# Altium schematic sheet Relay.SchDoc
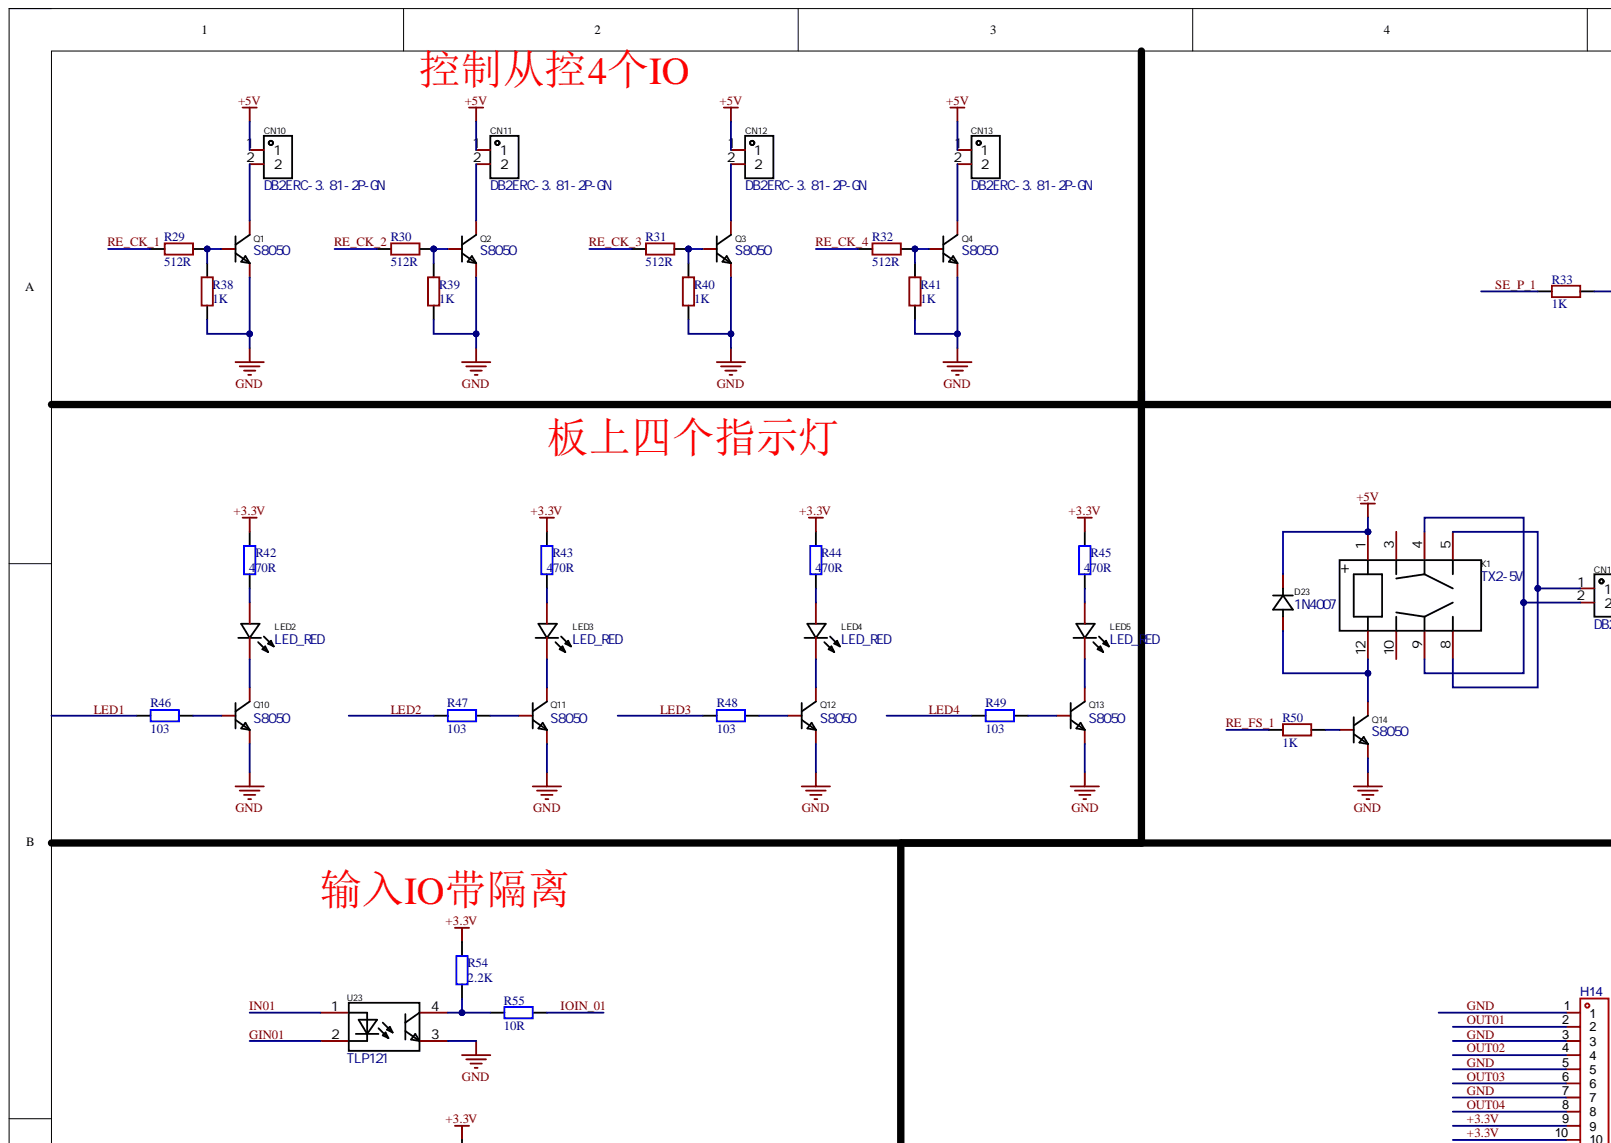
[diagram p0: Relay.SchDoc, top left]
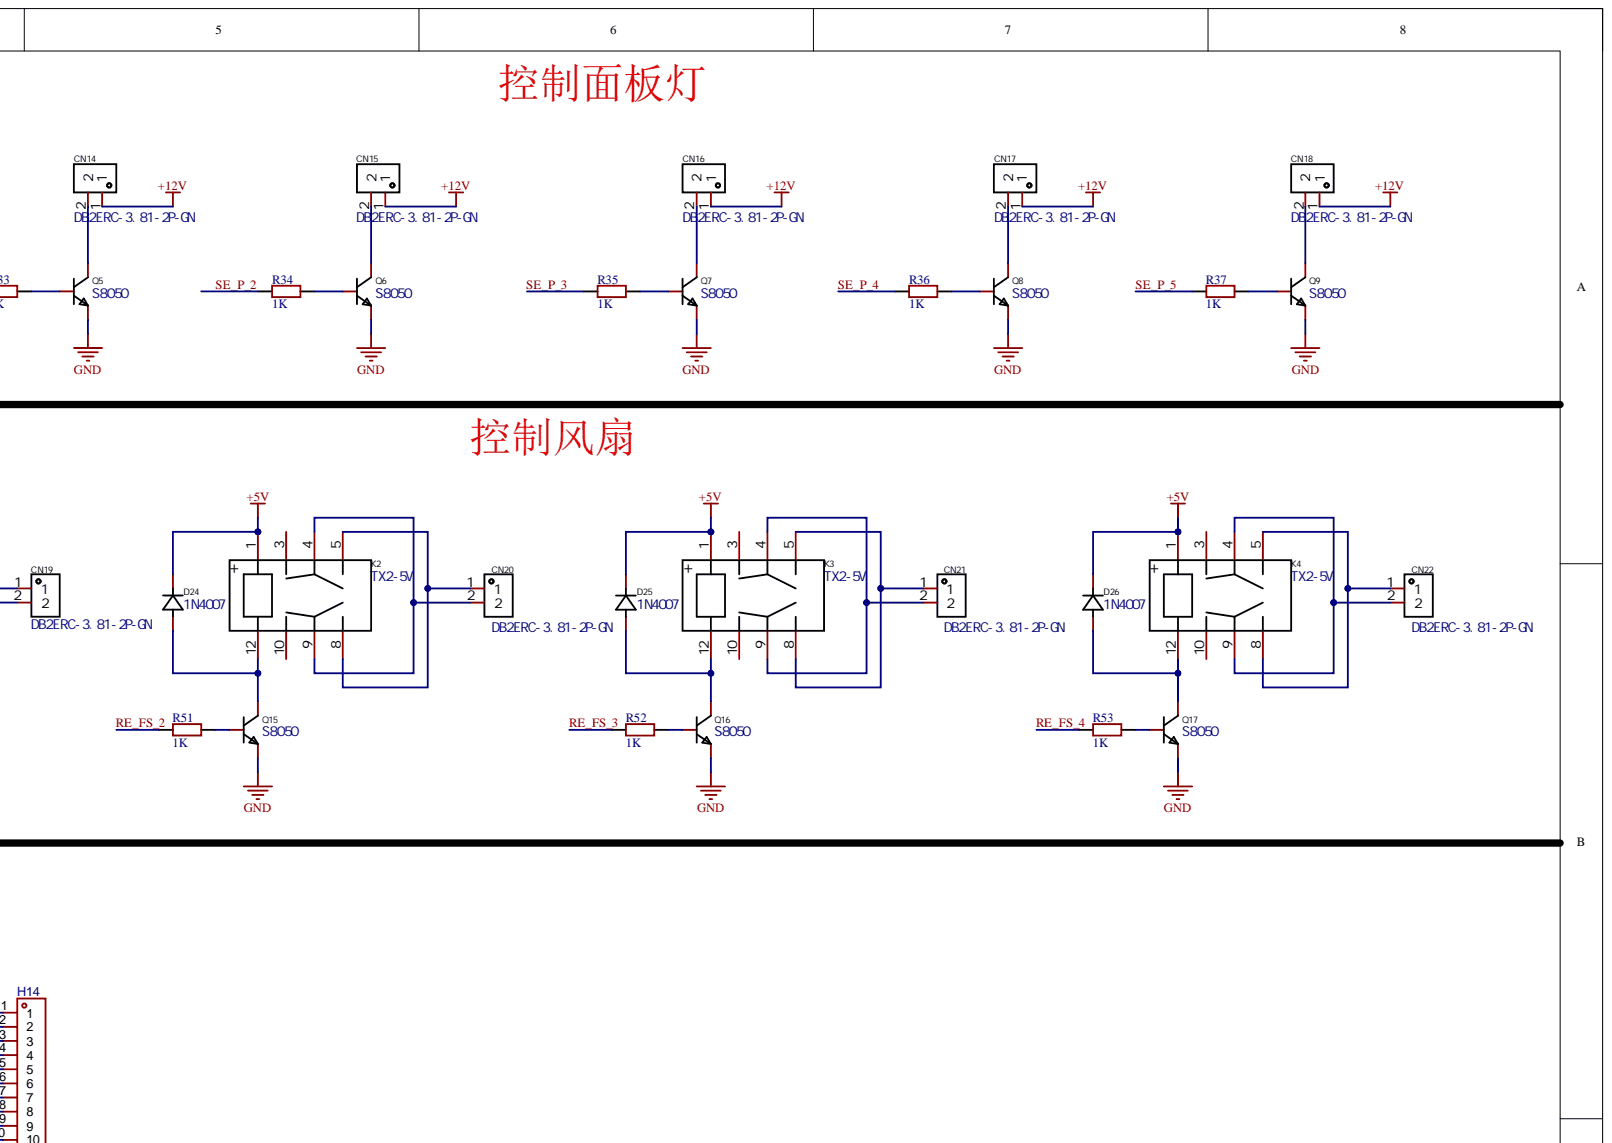
[diagram p1: Relay.SchDoc, top right]
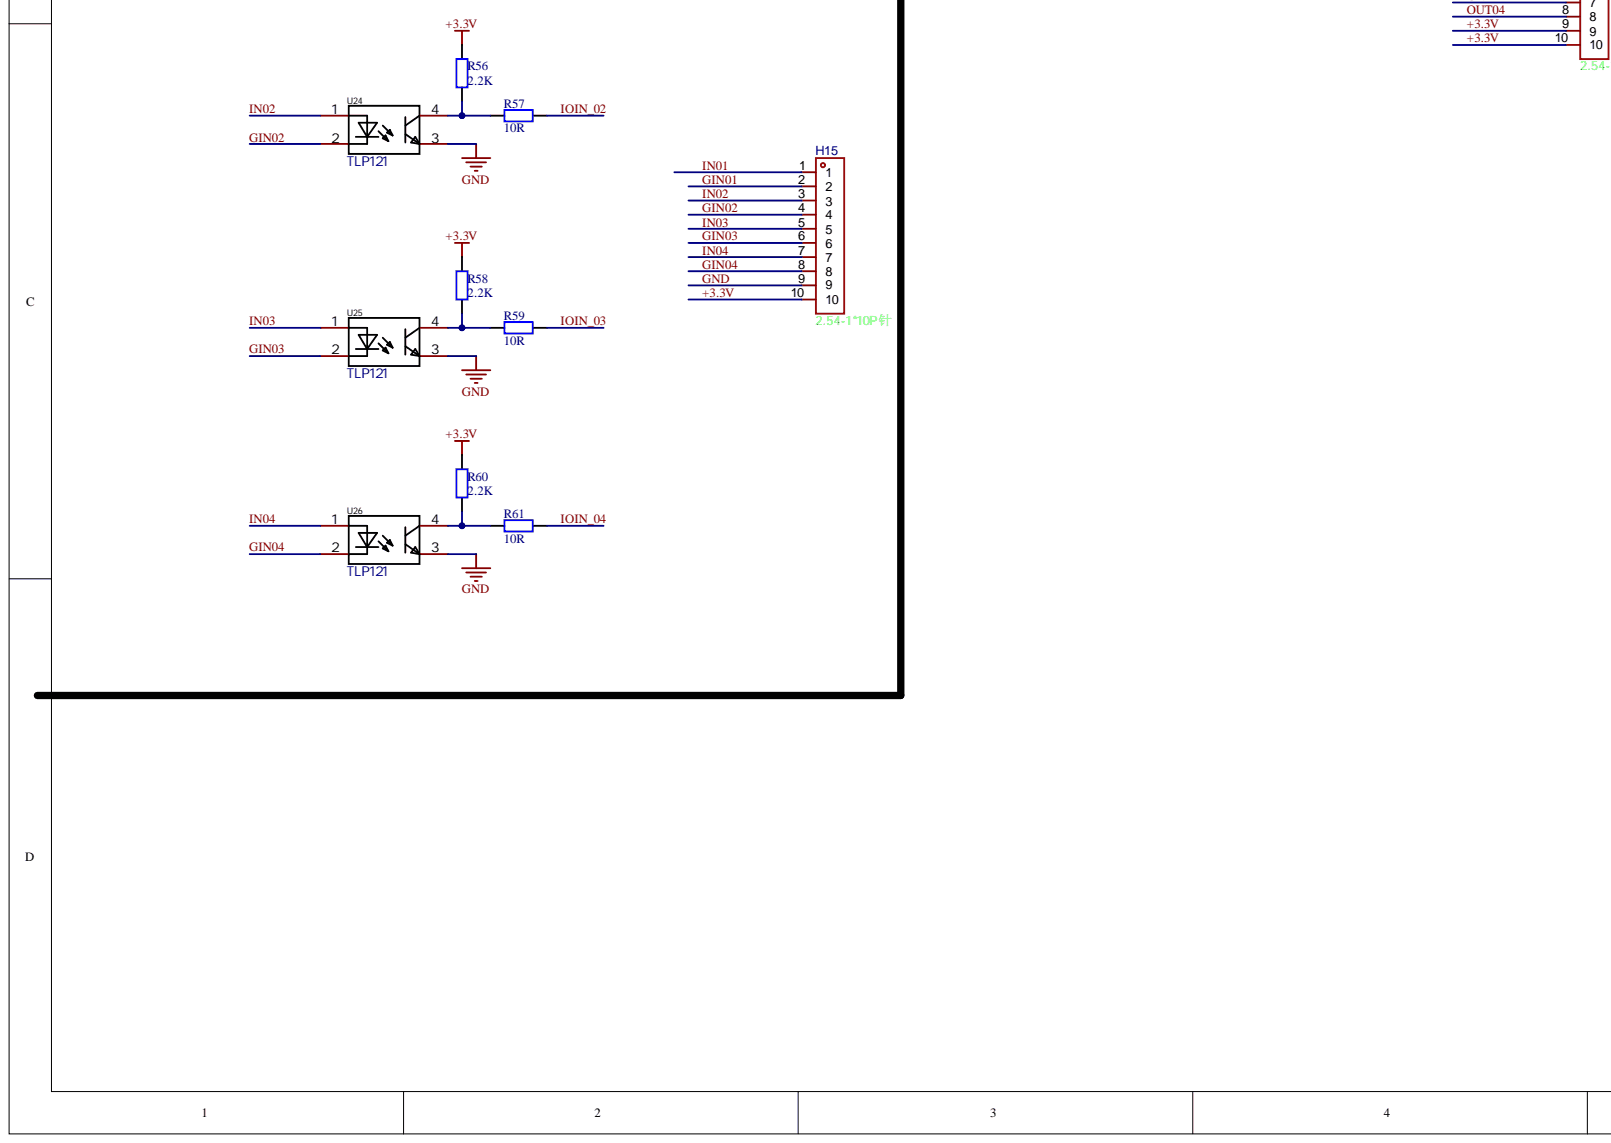
[diagram p2: Relay.SchDoc, bottom left]
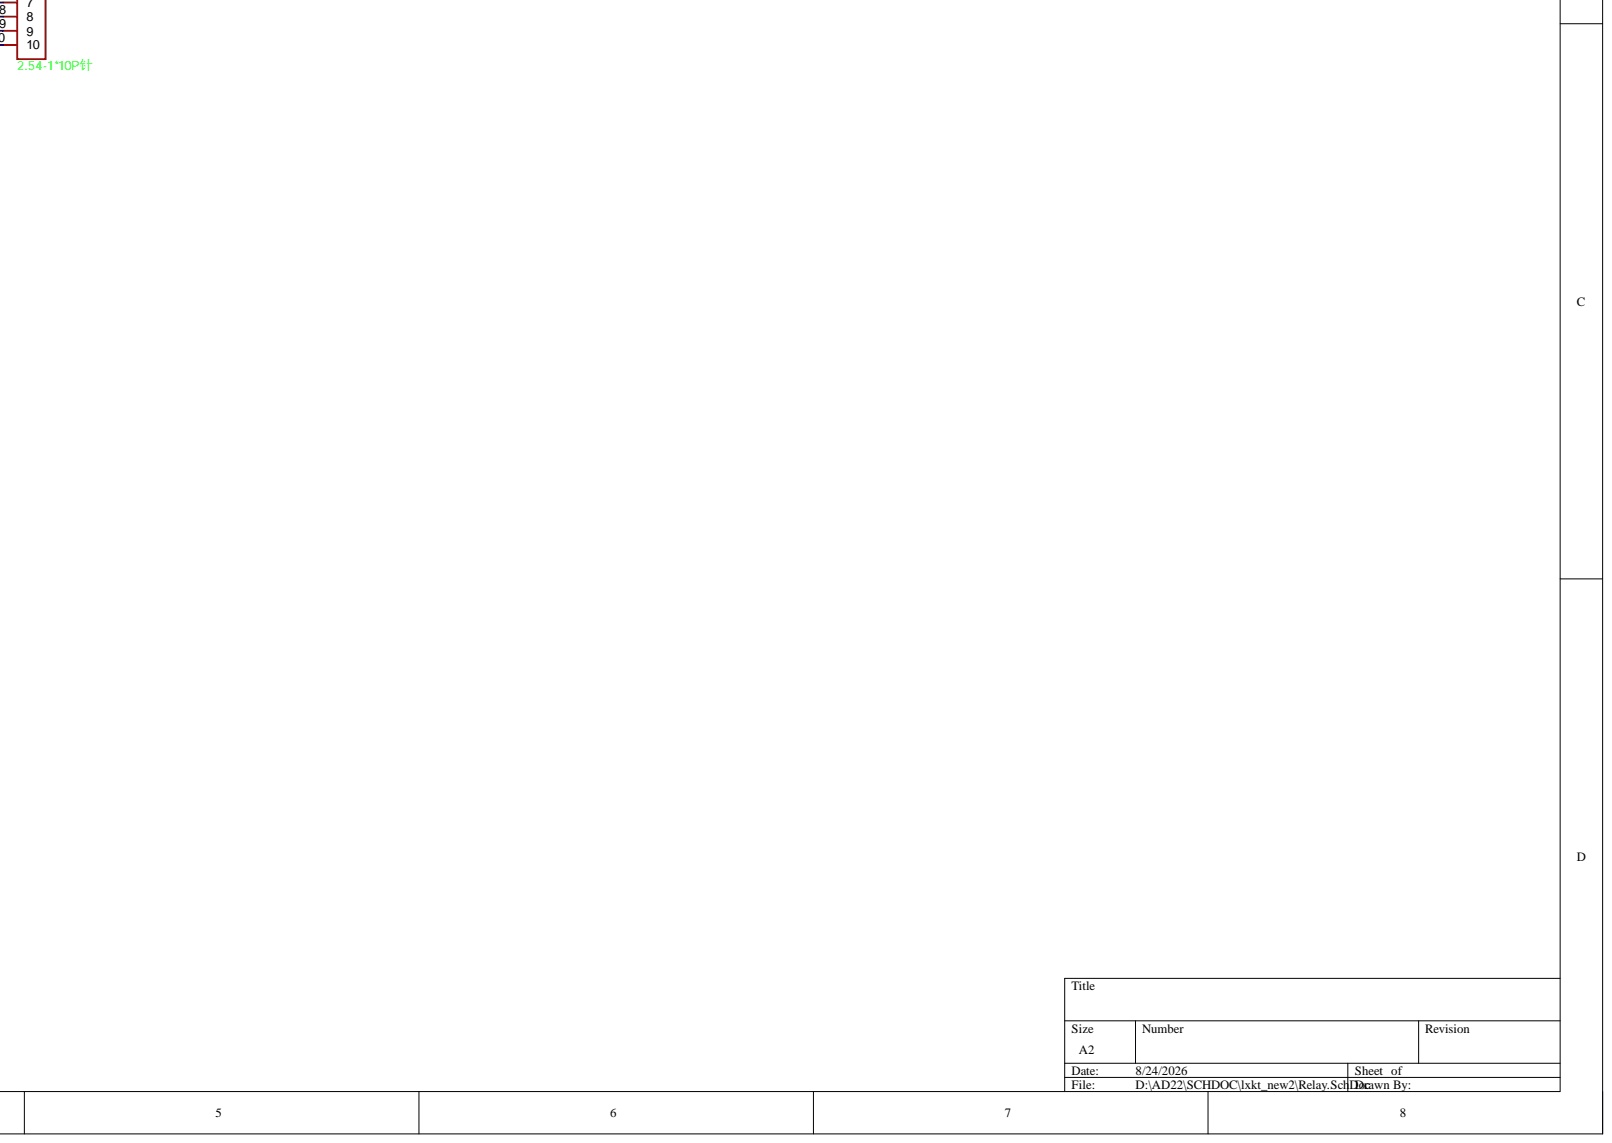
[diagram p3: Relay.SchDoc, bottom right]

## Parts
CN10  DB2ERC-3.81-2P-GN  [CONN-TH_2P-P3.81_DB2ERC-3.81-2P]
CN11  DB2ERC-3.81-2P-GN  [CONN-TH_2P-P3.81_DB2ERC-3.81-2P]
CN12  DB2ERC-3.81-2P-GN  [CONN-TH_2P-P3.81_DB2ERC-3.81-2P]
CN13  DB2ERC-3.81-2P-GN  [CONN-TH_2P-P3.81_DB2ERC-3.81-2P]
CN14  DB2ERC-3.81-2P-GN  [CONN-TH_2P-P3.81_DB2ERC-3.81-2P]
CN15  DB2ERC-3.81-2P-GN  [CONN-TH_2P-P3.81_DB2ERC-3.81-2P]
CN16  DB2ERC-3.81-2P-GN  [CONN-TH_2P-P3.81_DB2ERC-3.81-2P]
CN17  DB2ERC-3.81-2P-GN  [CONN-TH_2P-P3.81_DB2ERC-3.81-2P]
CN18  DB2ERC-3.81-2P-GN  [CONN-TH_2P-P3.81_DB2ERC-3.81-2P]
CN19  DB2ERC-3.81-2P-GN  [CONN-TH_2P-P3.81_DB2ERC-3.81-2P]
CN20  DB2ERC-3.81-2P-GN  [CONN-TH_2P-P3.81_DB2ERC-3.81-2P]
CN21  DB2ERC-3.81-2P-GN  [CONN-TH_2P-P3.81_DB2ERC-3.81-2P]
CN22  DB2ERC-3.81-2P-GN  [CONN-TH_2P-P3.81_DB2ERC-3.81-2P]
D23  1N4007  [SMA_L4.3-W2.5-LS5.0-RD]
D24  1N4007  [SMA_L4.3-W2.5-LS5.0-RD]
D25  1N4007  [SMA_L4.3-W2.5-LS5.0-RD]
D26  1N4007  [SMA_L4.3-W2.5-LS5.0-RD]
H14  2.54-1*10P针  [HDR-TH_10P-P2.54-V-M]
H15  2.54-1*10P针  [HDR-TH_10P-P2.54-V-M]
K1  TX2-5V  [RELAY-TH_TX2-3V]
K2  TX2-5V  [RELAY-TH_TX2-3V]
K3  TX2-5V  [RELAY-TH_TX2-3V]
K4  TX2-5V  [RELAY-TH_TX2-3V]
LED2  LED_RED  [LED0805-RD_RED]
LED3  LED_RED  [LED0805-RD_RED]
LED4  LED_RED  [LED0805-RD_RED]
LED5  LED_RED  [LED0805-RD_RED]
Q1  S8050  [SOT-23-3_L2.9-W1.6-P1.90-LS2.8-BR]
Q2  S8050  [SOT-23-3_L2.9-W1.6-P1.90-LS2.8-BR]
Q3  S8050  [SOT-23-3_L2.9-W1.6-P1.90-LS2.8-BR]
Q4  S8050  [SOT-23-3_L2.9-W1.6-P1.90-LS2.8-BR]
Q5  S8050  [SOT-23-3_L2.9-W1.6-P1.90-LS2.8-BR]
Q6  S8050  [SOT-23-3_L2.9-W1.6-P1.90-LS2.8-BR]
Q7  S8050  [SOT-23-3_L2.9-W1.6-P1.90-LS2.8-BR]
Q8  S8050  [SOT-23-3_L2.9-W1.6-P1.90-LS2.8-BR]
Q9  S8050  [SOT-23-3_L2.9-W1.6-P1.90-LS2.8-BR]
Q10  S8050  [SOT-23-3_L2.9-W1.6-P1.90-LS2.8-BR]
Q11  S8050  [SOT-23-3_L2.9-W1.6-P1.90-LS2.8-BR]
Q12  S8050  [SOT-23-3_L2.9-W1.6-P1.90-LS2.8-BR]
Q13  S8050  [SOT-23-3_L2.9-W1.6-P1.90-LS2.8-BR]
Q14  S8050  [SOT-23-3_L2.9-W1.6-P1.90-LS2.8-BR]
Q15  S8050  [SOT-23-3_L2.9-W1.6-P1.90-LS2.8-BR]
Q16  S8050  [SOT-23-3_L2.9-W1.6-P1.90-LS2.8-BR]
Q17  S8050  [SOT-23-3_L2.9-W1.6-P1.90-LS2.8-BR]
R29  512R  [0805_R]
R30  512R  [0805_R]
R31  512R  [0805_R]
R32  512R  [0805_R]
R33  1K  [0805_R]
R34  1K  [0805_R]
R35  1K  [0805_R]
R36  1K  [0805_R]
R37  1K  [0805_R]
R38  1K  [0805_R]
R39  1K  [0805_R]
R40  1K  [0805_R]
R41  1K  [0805_R]
R42  470R  [0805_R]
R43  470R  [0805_R]
R44  470R  [0805_R]
R45  470R  [0805_R]
R46  103  [0805_R]
R47  103  [0805_R]
R48  103  [0805_R]
R49  103  [0805_R]
R50  1K  [0805_R]
R51  1K  [0805_R]
R52  1K  [0805_R]
R53  1K  [0805_R]
R54  2.2K  [0805_R]
R55  10R  [0805_R]
R56  2.2K  [0805_R]
R57  10R  [0805_R]
R58  2.2K  [0805_R]
R59  10R  [0805_R]
R60  2.2K  [0805_R]
R61  10R  [0805_R]
U23  TLP121  [OPTO-SMD-4_L4.4-W4.1-P2.54-LS7.0-TL]
U24  TLP121  [OPTO-SMD-4_L4.4-W4.1-P2.54-LS7.0-TL]
U25  TLP121  [OPTO-SMD-4_L4.4-W4.1-P2.54-LS7.0-TL]
U26  TLP121  [OPTO-SMD-4_L4.4-W4.1-P2.54-LS7.0-TL]

## Nets
+5V: CN10.1 CN11.1 CN12.1 CN13.1 D23.1 D24.1 D25.1 D26.1 K1.1 K2.1 K3.1 K4.1
+12V: CN14.1 CN15.1 CN16.1 CN17.1 CN18.1
+3.3V: H14.9 H14.10 H15.10 R42.1 R43.1 R44.1 R45.1 R54.1 R56.1 R58.1 R60.1
GIN01: H15.2 U23.2
GIN02: H15.4 U24.2
GIN03: H15.6 U25.2
GIN04: H15.8 U26.2
GND: H14.1 H14.3 H14.5 H14.7 H15.9 Q1.2 Q2.2 Q3.2 Q4.2 Q5.2 Q6.2 Q7.2 Q8.2 Q9.2 Q10.2 Q11.2 Q12.2 Q13.2 Q14.2 Q15.2 Q16.2 Q17.2 R38.1 R39.1 R40.1 R41.1 U23.3 U24.3 U25.3 U26.3
IN01: H15.1 U23.1
IN02: H15.3 U24.1
IN03: H15.5 U25.1
IN04: H15.7 U26.1
IOIN_01: R55.1
IOIN_02: R57.1
IOIN_03: R59.1
IOIN_04: R61.1
LED1: R46.2
LED2: R47.2
LED3: R48.2
LED4: R49.2
OUT01: H14.2
OUT02: H14.4
OUT03: H14.6
OUT04: H14.8
RE_CK_1: R29.1
RE_CK_2: R30.1
RE_CK_3: R31.1
RE_CK_4: R32.1
RE_FS_1: R50.1
RE_FS_2: R51.1
RE_FS_3: R52.1
RE_FS_4: R53.1
SE_P_1: R33.1
SE_P_2: R34.1
SE_P_3: R35.1
SE_P_4: R36.1
SE_P_5: R37.1
NetCN10_2: CN10.2 Q1.3
NetCN11_2: CN11.2 Q2.3
NetCN12_2: CN12.2 Q3.3
NetCN13_2: CN13.2 Q4.3
NetCN14_2: CN14.2 Q5.3
NetCN15_2: CN15.2 Q6.3
NetCN16_2: CN16.2 Q7.3
NetCN17_2: CN17.2 Q8.3
NetCN18_2: CN18.2 Q9.3
NetCN19_1: CN19.1 K1.5 K1.8
NetCN19_2: CN19.2 K1.4 K1.9
NetCN20_1: CN20.1 K2.5 K2.8
NetCN20_2: CN20.2 K2.4 K2.9
NetCN21_1: CN21.1 K3.5 K3.8
NetCN21_2: CN21.2 K3.4 K3.9
NetCN22_1: CN22.1 K4.5 K4.8
NetCN22_2: CN22.2 K4.4 K4.9
NetD23_2: D23.2 K1.12 Q14.3
NetD24_2: D24.2 K2.12 Q15.3
NetD25_2: D25.2 K3.12 Q16.3
NetD26_2: D26.2 K4.12 Q17.3
NetLED2_1: LED2.1 Q10.3
NetLED2_2: LED2.2 R42.2
NetLED3_1: LED3.1 Q11.3
NetLED3_2: LED3.2 R43.2
NetLED4_1: LED4.1 Q12.3
NetLED4_2: LED4.2 R44.2
NetLED5_1: LED5.1 Q13.3
NetLED5_2: LED5.2 R45.2
NetQ1_1: Q1.1 R29.2 R38.2
NetQ2_1: Q2.1 R30.2 R39.2
NetQ3_1: Q3.1 R31.2 R40.2
NetQ4_1: Q4.1 R32.2 R41.2
NetQ5_1: Q5.1 R33.2
NetQ6_1: Q6.1 R34.2
NetQ7_1: Q7.1 R35.2
NetQ8_1: Q8.1 R36.2
NetQ9_1: Q9.1 R37.2
NetQ10_1: Q10.1 R46.1
NetQ11_1: Q11.1 R47.1
NetQ12_1: Q12.1 R48.1
NetQ13_1: Q13.1 R49.1
NetQ14_1: Q14.1 R50.2
NetQ15_1: Q15.1 R51.2
NetQ16_1: Q16.1 R52.2
NetQ17_1: Q17.1 R53.2
NetR54_2: R54.2 R55.2 U23.4
NetR56_2: R56.2 R57.2 U24.4
NetR58_2: R58.2 R59.2 U25.4
NetR60_2: R60.2 R61.2 U26.4

Not connected: K1 3 10; K2 3 10; K3 3 10; K4 3 10

## Library data of the parts
CN10-CN22 = DB2ERC-3.81-2P-GN: 插拔式接线端子3.81 立式/卧式; Manufacturer: DIBO(地博电气); Add into BOM: yes; Connector Type: 板端/插座-闭口; Current Rating (Max): 10A; Number of PINs Per Row: 2; Pitch: 3.81mm; Structure: 1x2P; Voltage Rating (Max): 250V; Color: 绿色; Component Kind: Standard; Convert to PCB: yes; Datasheet: https://atta.szlcsc.com/upload/public/pdf/source/20221115/4E217E7D538220F8720C137D6A05E519.pdf; Device: DB2ERC-3.81-2P-GN; JLCPCB Part Class: Extended Part; LCSC Part Name: 板端/插座-闭口 1x2P 3.81mm 排数:1 每排P数:2 弯针; Library Name: pro24.IntLib; Manufacturer Part: DB2ERC-3.81-2P-GN; Mounting Style: 弯针; Name: DB2ERC-3.81-2P-GN; Number of Rows: 1; Operating Temperature Range: -40℃~+105℃; Supplier: LCSC; Supplier Footprint: 弯插,P=3.81mm; Supplier Part: C395684; Symbol: DB2ERC-3.81-2P
D23-D26 = 1N4007: 通用二极管; Manufacturer: TWGMC(台湾迪嘉); Add into BOM: yes; Average Rectified Current (Io): 1A; Device: 1N4007_C727081; Diode Configuration: 独立式; Forward Voltage (Vf@If): 1.1V@1A; Reverse Leakage Current: 5uA@1kV; Reverse Voltage (Vr): 1kV; Component Kind: Standard; Convert to PCB: yes; Datasheet: https://atta.szlcsc.com/upload/public/pdf/source/20200828/C727079_3A05254382567072883400655AE0ED46.pdf; JLCPCB Part Class: Extended Part; LCSC Part Name: 1kV 1A 1.1V@1A; Manufacturer Part: 1N4007; Name: 1N4007; Supplier: LCSC; Supplier Footprint: SMA(DO-214AC); Supplier Part: C727081; Symbol: 1N4007_C727081; Library Name: WXcharge0210.IntLib
H14 H15 = 2.54-1*10P针: 排针; Symbol: PZ254V-11-10P; Component Kind: Standard; Library Name: WXcharge0210.IntLib
K1-K4 = TX2-5V: 信号继电器; Manufacturer: PANASONIC(松下); Add into BOM: yes; Coil Voltage: 5V; Contact Form: 两组转换:2C(双刀双掷-转换); Maximum Switching Current: 2A; Maximum Switching Voltage: 220V@DC; Operate Time: 4ms; Coil Resistance: -; Coil Type: -; Component Kind: Standard; Contact Material: 银+镀金; Contact Rating: -; Contact Resistance: -; Convert to PCB: yes; Datasheet: https://atta.szlcsc.com/upload/public/pdf/source/20180524/C192257_90ABE86BE073F309F1A3B364AFE43747.pdf; Device: TX2-5V; JLCPCB Part Class: Extended Part; LCSC Part Name: TX2-5V; Manufacturer Part: TX2-5V; Operating Temperature: -40℃~+85℃; Pin Number: -; Release Time: 4ms; Supplier: LCSC; Supplier Footprint: DIP,7.4x15mm; Supplier Part: C192257; Symbol: TX2-5V; Library Name: lxkt.IntLib
LED2-LED5 = LED_0805_RED: 发光二极管; Manufacturer: 国星光电; Add into BOM: yes; Device: NCD0805R1; Emitted Color: 红灯; Symbol: FC-2012HRK-620D; Component Kind: Standard; Convert to PCB: yes; Datasheet: https://atta.szlcsc.com/upload/public/pdf/source/20200820/C84256_F0A75A43B3EAD75808600A0514C76B99.pdf; JLCPCB Part Class: Basic Part; LCSC Part Name: 红灯; Library Name: pro24.IntLib; Manufacturer Part: NCD0805R1; Name: NCD0805R1; Supplier: LCSC; Supplier Footprint: 0805; Supplier Part: C84256
Q1-Q17 = S8050: NPN; Manufacturer: CJ(江苏长电/长晶); Add into BOM: yes; Collector Current (Ic): 500mA; Collector Cut-Off Current (Icbo): 100nA; Collector-Emitter Breakdown Voltage (Vceo): 25V; Collector-Emitter Saturation Voltage (VCE(sat)@Ic,Ib): 600mV@500mA,50mA; DC Current Gain (hFE@Ic,Vce): 120@50mA,1V; Device: S8050_C105433; Power Dissipation (Pd): 300mW; Transition Frequency (fT): 150MHz; Component Kind: Standard; Convert to PCB: yes; Datasheet: https://atta.szlcsc.com/upload/public/pdf/source/20170415/1492237762328.pdf; JLCPCB Part Class: Extended Part; LCSC Part Name: NPN 25V 500mA L档 120-200; Manufacturer Part: S8050; Name: S8050; Operating Temperature: +150℃@(Tj); Supplier: LCSC; Supplier Footprint: SOT-23; Supplier Part: C105433; Symbol: S8050_C105433; Transistor Type: NPN; Library Name: fenrong0303_Library.IntLib
R29-R41 R50-R53 = R 0603 0Ω (0R0) 1%: 贴片电阻; Component Kind: Standard; ComponentLink1Description: 供应商链接; ComponentLink1URL: http://www.szlcsc.com/product/details_21903.html; Library Name: BasicComponents2205.IntLib; Package: 0603; Supplier: LC; SuppliersPartNumber: C21189
R42-R49 R54-R61 = 0805 10KΩ (1002) 1%: 贴片电阻; Component Kind: Standard; ComponentLink1Description: 供应商链接; ComponentLink1URL: http://www.szlcsc.com/product/details_18102.html; Package: 0805; Supplier: LC; SuppliersPartNumber: C17414; Library Name: JiaLiChuang.IntLib
U23-U26 = TLP121: 光耦; Manufacturer: TOSHIBA(东芝); Add into BOM: yes; Collector-Emitter Saturation Voltage (Vce(sat)@Ic,IF): 400mV@2.4mA,8mA; Device: TLP121(GB-TPL,F); Fall time: 3us@2mA,100Ω; Forward Voltage: 1.15V; Input voltage type: DC; Isolation Voltage(rms): 3.75kV; Number of Outputs: 1; Output Current: 50mA; Output Type: 光电三极管; Receiving End Voltage: 80V; Reverse Voltage: 5V; Rise time: 2us@2mA,100Ω; Symbol: TLP121(GB-TPL.F); Component Kind: Standard; Convert to PCB: yes; Datasheet: https://atta.szlcsc.com/upload/public/pdf/source/20210408/C2762684_DAC74D7D20D0C8826FA8973C9611CEC5.pdf; JLCPCB Part Class: Extended Part; LCSC Part Name: DC输入 隔离电压(rms):3750V; Library Name: pro24.IntLib; Manufacturer Part: TLP121(GB-TPL,F); Name: TLP121(GB-TPL,F); Operating Temperature: -55℃~+100℃; Supplier: LCSC; Supplier Footprint: SOP-4; Supplier Part: C2762684
